# Altium schematic sheet usb-debug.SchDoc(usb-debug)
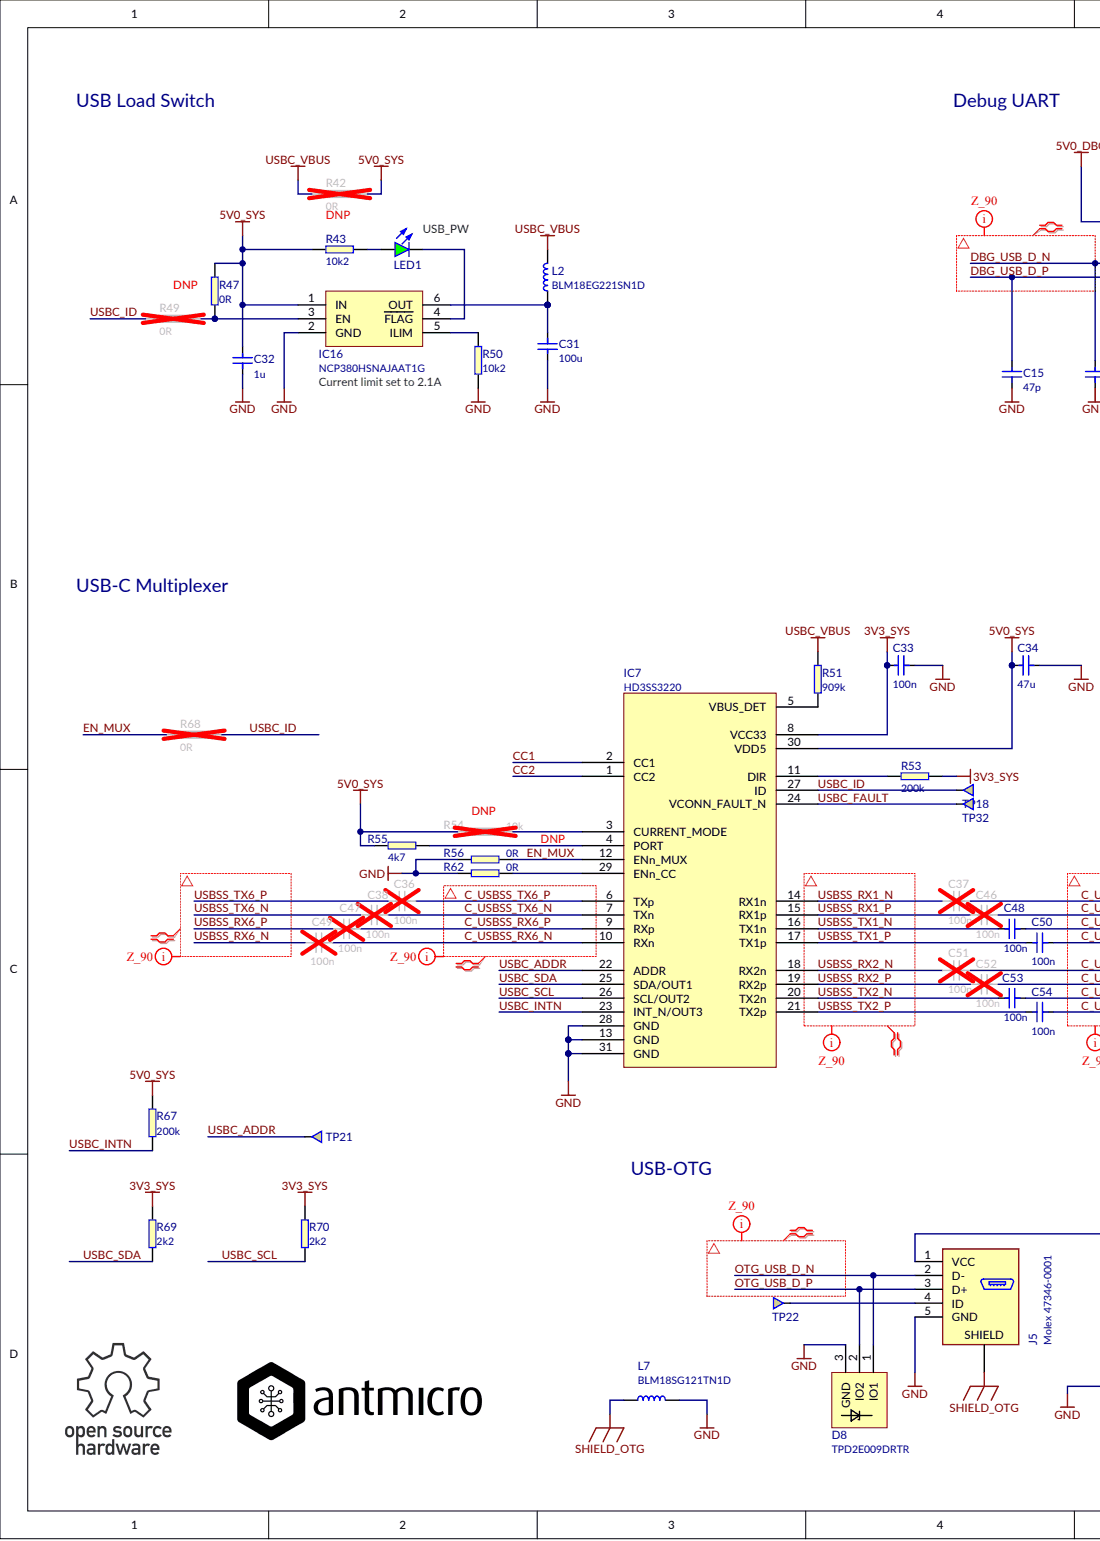
[diagram p0: usb-debug.SchDoc, left]
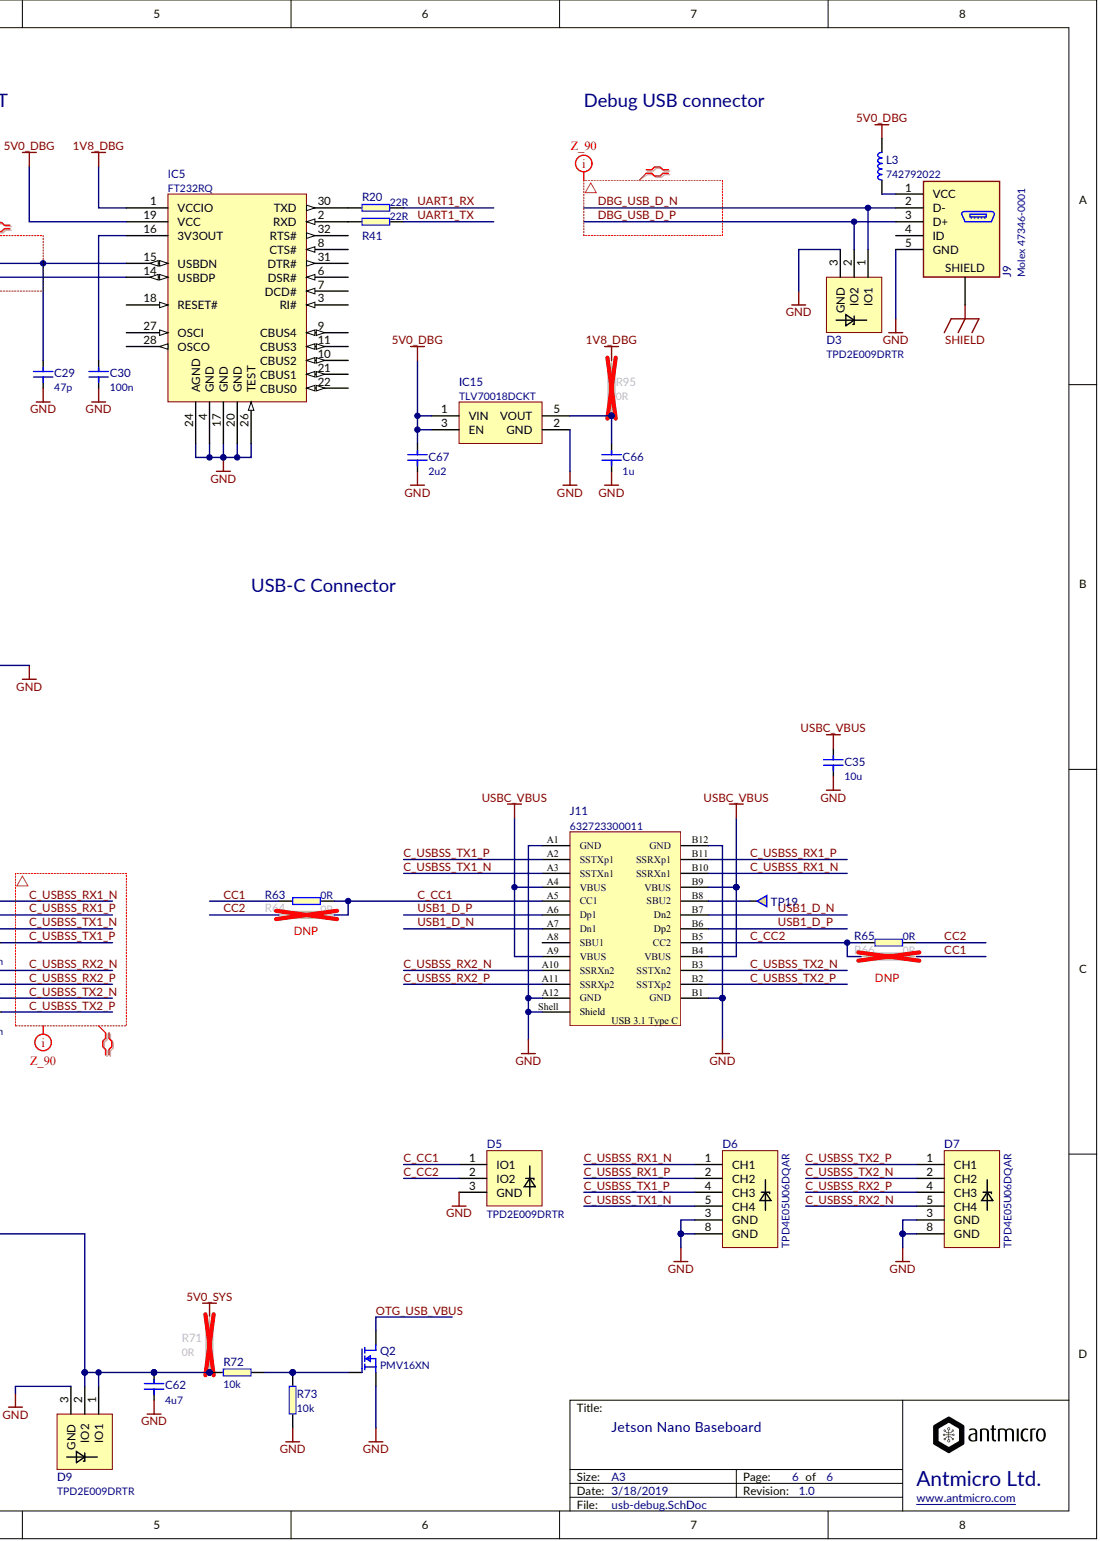
[diagram p1: usb-debug.SchDoc, right]

## Parts
C15  47p
C29  47p
C30  100n
C31  100u
C32  1u
C33  100n
C34  47u
C35  10u
C36  100n
C37  100n  (value not drawn)
C38  100n
C46  100n
C47  100n
C48  100n
C49  100n
C50  100n
C51  100n  (value not drawn)
C52  100n
C53  100n
C54  100n
C62  4u7
C66  1u
C67  2u2
D3  TPD2E009DRTR
D5  TPD2E009DRTR
D6  PartSymbol: TPD4E05U06DQAR  (hidden comment: TPD4E05U06)
D7  PartSymbol: TPD4E05U06DQAR  (hidden comment: TPD4E05U06)
D8  TPD2E009DRTR
D9  TPD2E009DRTR
IC5  FT232RQ
IC7  HD3SS3220
IC15  TLV70018DCKT
IC16  NCP380HSNAJAAT1G
J5  Manufacturer: Molex  (hidden comment: Molex 47346-0001)
J9  Manufacturer: Molex  (hidden comment: Molex 47346-0001)
J11  632723300011
L2  BLM18EG221SN1D
L3  742792022
L7  BLM18SG121TN1D
LED1  KPT-1608SGC  (value not drawn)
Q2  PMV16XN
R20  22R
R41  22R
R42  0R
R43  10k2
R47  0R
R49  0R
R50  10k2
R51  909k
R53  200k
R54  10k
R55  4k7
R56  0R
R62  0R
R63  0R
R64  0R
R65  0R
R66  0R
R67  200k
R68  0R
R69  2k2
R70  2k2
R71  0R
R72  10k
R73  10k
R95  0R
TP18  Testpad  (value not drawn)
TP19  Testpad  (value not drawn)
TP21  Testpad  (value not drawn)
TP22  Testpad  (value not drawn)
TP32  Testpad  (value not drawn)

## Nets
1V8_DBG: IC5.1(VCCIO) R95.2
3V3_SYS: C33.2 IC7.8(VCC33) R53.2 R69.2 R70.2
5V0_DBG: C67.2 IC5.19(VCC) IC15.1(VIN) IC15.3(EN) L3.2
5V0_SYS: C32.2 C34.2 IC7.30(VDD5) IC16.1(IN) R42.2 R43.2 R47.2 R54.1 R55.1 R67.2 R71.2
GND: C15.1 C29.1 C30.1 C31.2 C32.1 C33.1 C34.1 C35.1 C62.1 C66.1 C67.1 D3.3 D5.3 D6.3(GND) D6.8(GND) D7.3(GND) D7.8(GND) D8.3 D9.3 IC5.4(GND) IC5.17(GND) IC5.20(GND) IC5.24(AGND) IC5.26(TEST) IC7.13(GND) IC7.28(GND) IC7.31(GND) IC15.2(GND) IC16.2(GND) J5.5(GND) J9.5(GND) J11.A1(GND) J11.A12(GND) J11.B1(GND) J11.B12(GND) J11.Shell(Shield) L7.2 Q2.2 R50.1 R56.1 R62.1 R73.1
SHIELD: J9.S
SHIELD_OTG: J5.S L7.1
USBC_VBUS: C35.2 J11.A4(VBUS) J11.A9(VBUS) J11.B4(VBUS) J11.B9(VBUS) L2.2 R42.1 R51.2
C_CC1: D5.1 J11.A5(CC1) R63.2 R64.2
C_CC2: D5.2 J11.B5(CC2) R65.1 R66.1
C_USBSS_RX1_N: C37.1 D6.1(CH1) J11.B10(SSRXn1)
C_USBSS_RX1_P: C46.1 D6.2(CH2) J11.B11(SSRXp1)
C_USBSS_RX2_N: C51.1 D7.5(CH4) J11.A10(SSRXn2)
C_USBSS_RX2_P: C52.1 D7.4(CH3) J11.A11(SSRXp2)
C_USBSS_RX6_N: C49.1 IC7.10(RXn)
C_USBSS_RX6_P: C47.1 IC7.9(RXp)
C_USBSS_TX1_N: C48.1 D6.5(CH4) J11.A3(SSTXn1)
C_USBSS_TX1_P: C50.1 D6.4(CH3) J11.A2(SSTXp1)
C_USBSS_TX2_N: C53.1 D7.2(CH2) J11.B3(SSTXn2)
C_USBSS_TX2_P: C54.1 D7.1(CH1) J11.B2(SSTXp2)
C_USBSS_TX6_N: C38.1 IC7.7(TXn)
C_USBSS_TX6_P: C36.1 IC7.6(TXp)
CC1: IC7.2(CC1) R63.1 R66.2
CC2: IC7.1(CC2) R64.1 R65.2
DBG_USB_D_N: C29.2 D3.1 IC5.15(USBDN) J9.2(D-)
DBG_USB_D_P: C15.2 D3.2 IC5.14(USBDP) J9.3(D+)
EN_MUX: IC7.12(ENn_MUX) R56.2 R68.1
OTG_USB_D_N: D8.1 J5.2(D-)
OTG_USB_D_P: D8.2 J5.3(D+)
OTG_USB_VBUS: Q2.3
UART1_RX: R20.2
UART1_TX: R41.2
USB1_D_N: J11.A7(Dn1) J11.B7(Dn2)
USB1_D_P: J11.A6(Dp1) J11.B6(Dp2)
USBC_ADDR: IC7.22(ADDR) TP21.1
USBC_FAULT: IC7.24(VCONN_FAULT_N) TP32.1
USBC_ID: IC7.27(ID) R49.1 R68.2 TP18.1
USBC_INTN: IC7.23(INT_N/OUT3) R67.1
USBC_SCL: IC7.26(SCL/OUT2) R70.1
USBC_SDA: IC7.25(SDA/OUT1) R69.1
USBSS_RX1_N: C37.2 IC7.14(RX1n)
USBSS_RX1_P: C46.2 IC7.15(RX1p)
USBSS_RX2_N: C51.2 IC7.18(RX2n)
USBSS_RX2_P: C52.2 IC7.19(RX2p)
USBSS_RX6_N: C49.2
USBSS_RX6_P: C47.2
USBSS_TX1_N: C48.2 IC7.16(TX1n)
USBSS_TX1_P: C50.2 IC7.17(TX1p)
USBSS_TX2_N: C53.2 IC7.20(TX2n)
USBSS_TX2_P: C54.2 IC7.21(TX2p)
USBSS_TX6_N: C38.2
USBSS_TX6_P: C36.2
NetC30_2: C30.2 IC5.16(3V3OUT)
NetC31_1: C31.1 IC16.6(OUT) L2.1
NetC62_2: C62.2 D9.1 D9.2 J5.1(VCC) R71.1 R72.1
NetC66_2: C66.2 IC15.5(VOUT) R95.1
NetIC5_2: IC5.2(RXD) R41.1
NetIC5_30: IC5.30(TXD) R20.1
NetIC7_3: IC7.3(CURRENT_MODE) R54.2
NetIC7_4: IC7.4(PORT) R55.2
NetIC7_5: IC7.5(VBUS_DET) R51.1
NetIC7_11: IC7.11(DIR) R53.1
NetIC7_29: IC7.29(ENn_CC) R62.2
NetIC16_3: IC16.3(EN) R47.1 R49.2
NetIC16_4: IC16.4(FLAG) LED1.K
NetIC16_5: IC16.5(ILIM) R50.2
NetJ5_4: J5.4(ID) TP22.1
NetJ9_1: J9.1(VCC) L3.1
NetJ11_B8: J11.B8(SBU2) TP19.1
NetLED1_A: LED1.A R43.1
NetQ2_1: Q2.1 R72.2 R73.2
N00446:
N00447:
N00448:
N00449:
N00450:
N00451:
N00452:
N00453:
N00454:
N00455:
N00456:
N00457:
N00458:
N00459:

Not connected: IC5 3(RI#) 6(DSR#) 7(DCD#) 8(CTS#) 9(CBUS4) 10(CBUS2) 11(CBUS3) 18(RESET#) 21(CBUS1) 22(CBUS0) 27(OSCI) 28(OSCO) 31(DTR#) 32(RTS#); J9 4(ID); J11 A8(SBU1)

## Library data of the parts
C15 C29 = (no symbol name): Manufacturer: AVX; PartNumber: C_47p_0603; PartSymbol: 06031A470KAT2A; Value: 47p
C30 C33 = (no symbol name): Manufacturer: AVX; PartNumber: C_100n_0603; PartSymbol: 0603YC104KAZ2A; Value: 100n
C31 = (no symbol name): Manufacturer: SAMSUNG; PartNumber: C_100u_1210; PartSymbol: CL32A107MQVNNNE; Value: 100u
C32 = (no symbol name): Manufacturer: KEMET; PartNumber: C_1u_0603; PartSymbol: C0603C105M4PACTU; Value: 1u
C34 = (no symbol name): PartNumber: C_47u_0805; Manufacturer: KEMET; PartSymbol: C0805C476M9PACTU; Value: 47u
C35 = (no symbol name): PartNumber: C_10u_0603; Manufacturer: Walsin; PartSymbol: 0603X106M6R3CT; Value: 10u
C36-C38 C46-C54 = (no symbol name): Manufacturer: TDK; PartNumber: C_100n_0402; PartSymbol: C1005X5R0J104K050BA; Value: 100n
C62 = (no symbol name): PartNumber: C_4u7_0603; Manufacturer: MULTICOMP; PartSymbol: MC0603X475K6R3CT; Value: 4u7
C66 = (no symbol name): Manufacturer: MULTICOMP; PartNumber: C_1u_0402; PartSymbol: MC0402F105Z6R3CT; Value: 1u
C67 = (no symbol name): Manufacturer: TDK; PartNumber: C_2u2_0402; PartSymbol: C1005X5R1A225K050BC; Value: 2u2
D3 D5 D8 D9 = (no symbol name): Manufacturer: Texas Instruments; Value: TPD2E009DRTR; PartNumber: TPD2E009DRTR; PartSymbol: TPD2E009DRTR
D6 D7 = (no symbol name): PartNumber: TPD4E05U06_PASS; Manufacturer: Texas Instruments; Value: TPD4E05U06
IC5 = (no symbol name): Manufacturer: FTDI; PartSymbol: FT232RQ-REEL; Value: FT232RQ; PartNumber: FT232RQ
IC7 = (no symbol name): Manufacturer: Texas Instruments; PartSymbol: HD3SS3220RNHT; PartNumber: HD3SS3220; Value: HD3SS3220
IC15 = (no symbol name): Manufacturer: TEXAS INSTRUMENTS; Value: TLV70018DCKT; PartNumber: TLV70018DCKT; PartSymbol: TLV70018DCKT
IC16 = (no symbol name): Manufacturer: ON Semiconductor; Value: NCP380HSNAJAAT1G; PartNumber: NCP380HSNAJAAT1G; PartSymbol: NCP380HSNAJAAT1G
J5 J9 = (no symbol name): PartNumber: CON-Molex-47346-0001; PartSymbol: 473460001; Value: Molex 47346-0001
J11 = (no symbol name): PartNumber: WE_632723300011; Manufacturer: WURTH ELEKTRONIK; Value: 632723300011; PartSymbol: 632723300011
L2 L7 = (no symbol name): Manufacturer: MURATA
  L2: Value: BLM18EG221SN1D; PartNumber: BLM18EG221SN1D; PartSymbol: BLM18EG221SN1D
  L7: Value: BLM18SG121TN1D; PartNumber: BLM18SG121TN1D; PartSymbol: BLM18SG121TN1D
L3 = (no symbol name): Manufacturer: WURTH ELEKTRONIK; Value: 742792022; PartNumber: 742792022; PartSymbol: 742792022
LED1 = (no symbol name): Manufacturer: KINGBRIGHT; PartNumber: LED_G; Value: KPT-1608SGC; PartSymbol: KPT-1608SGC
Q2 = (no symbol name): Manufacturer: NXP; Value: PMV16XN; PartNumber: PMV16XN; PartSymbol: PMV16XN
R20 R41 = (no symbol name): Manufacturer: VISHAY; PartNumber: R_22R_0402; PartSymbol: CRCW040222R0FKED; Value: 22R
R42 R95 = (no symbol name): Manufacturer: BOURNS; PartNumber: R_0R_0603; PartSymbol: CR0603-J/-000ELF; Value: 0R
R43 = (no symbol name): Manufacturer: BOURNS; PartNumber: R_470R_0603; PartSymbol: CR0603-JW-471GLF; Tolerance: 0.1%; Value: 10k2
R47 R49 R56 R62-R66 R68 R71 = (no symbol name): PartNumber: R_0R_0402; Manufacturer: Panasonic; PartSymbol: ERJ2GE0R00X; Value: 0R
R50 = (no symbol name): PartNumber: R_5k6_0603; Manufacturer: Panasonic; PartSymbol: ERJ3GEYJ562V; Value: 10k2
R51 = (no symbol name): PartNumber: R_909k_0402; Manufacturer: Panasonic; PartSymbol: ERJ2RKF9093X; Value: 909k
R53 R67 = (no symbol name): PartNumber: R_200k_0402; Manufacturer: YAGEO; PartSymbol: RC0402FR-07200KL; Value: 200k
R54 R72 R73 = (no symbol name): PartNumber: R_10k_0402; Manufacturer: MULTICOMP; PartSymbol: MCMR04X1002FTL; Value: 10k
R55 = (no symbol name): PartNumber: R_4k7_0402; Manufacturer: Panasonic; PartSymbol: ERJ2GEJ472X; Value: 4k7
R69 R70 = (no symbol name): PartNumber: R_2k2_0402; Manufacturer: MULTICOMP; PartSymbol: MCWR04X2201FTL; Value: 2k2
TP18 TP19 TP21 TP22 TP32 = (no symbol name): PartNumber: TP-R-1.5
